# Altium schematic sheet MainProcessor.SchDoc(MainProcessor)
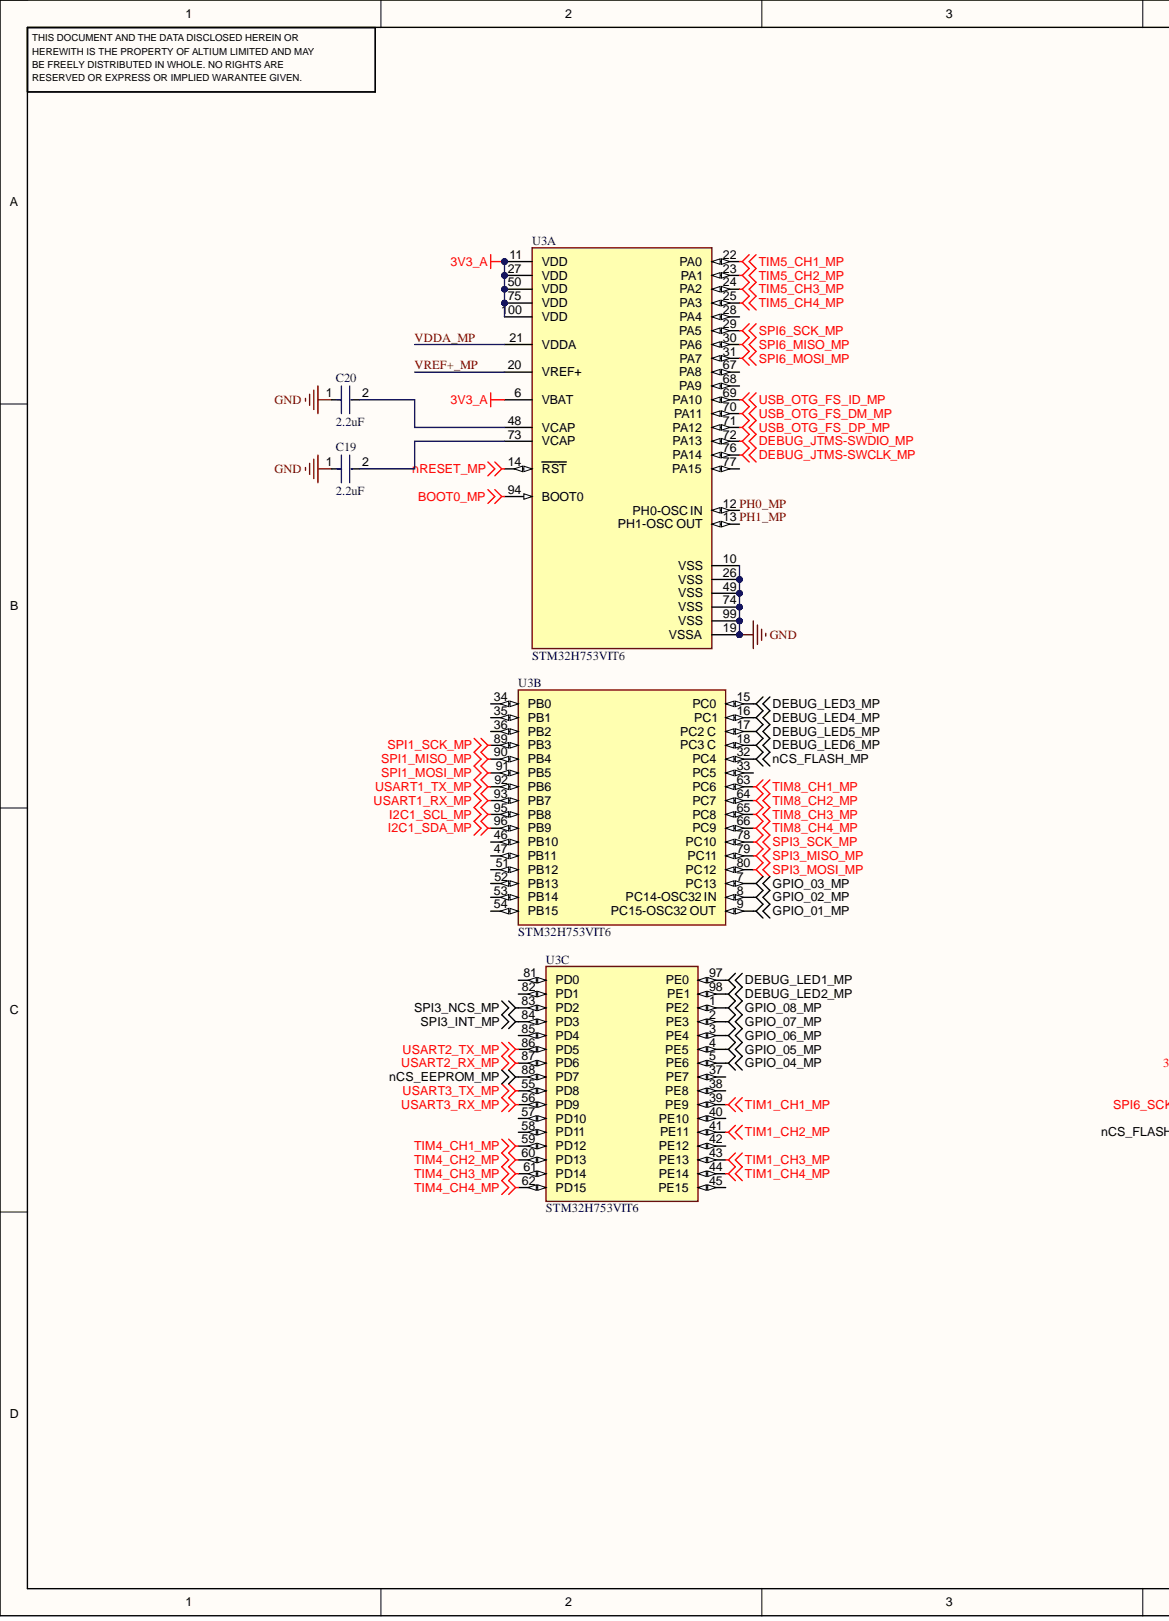
[diagram p0: MainProcessor.SchDoc, left]
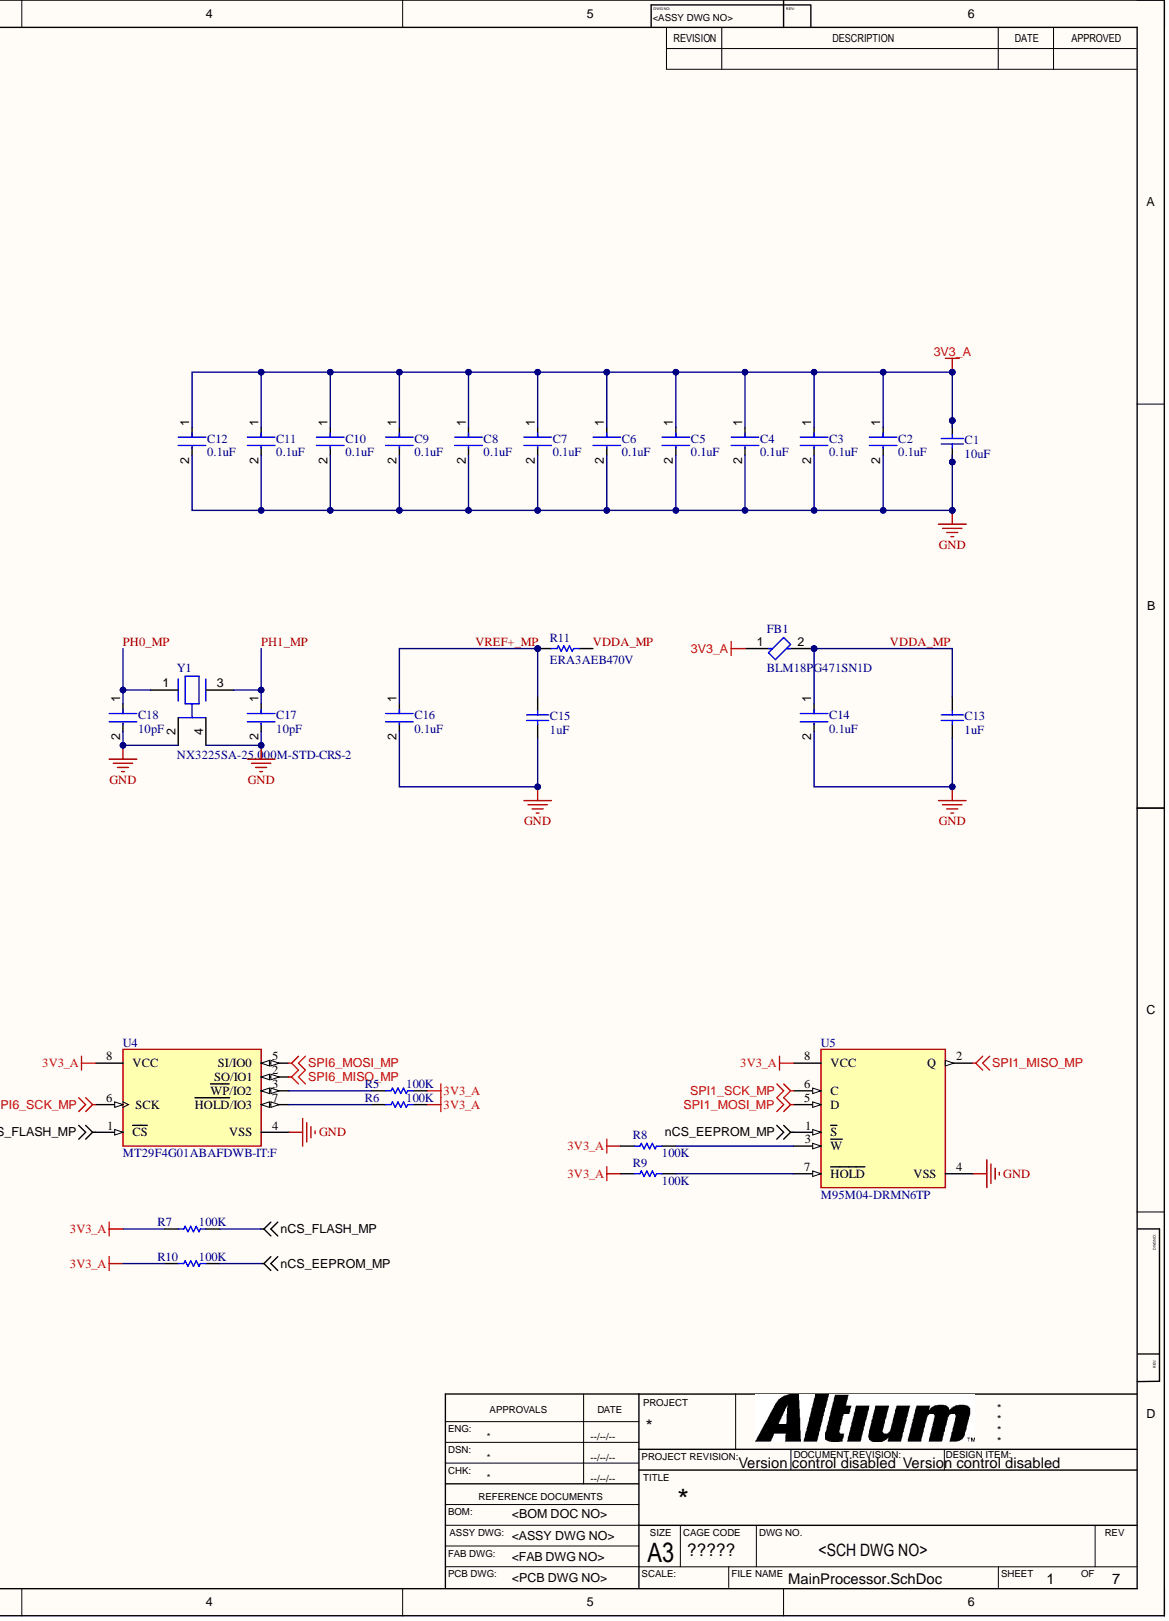
[diagram p1: MainProcessor.SchDoc, right]

## Parts
C1  10uF
C2  0.1uF
C3  0.1uF
C4  0.1uF
C5  0.1uF
C6  0.1uF
C7  0.1uF
C8  0.1uF
C9  0.1uF
C10  0.1uF
C11  0.1uF
C12  0.1uF
C13  1uF
C14  0.1uF
C15  1uF
C16  0.1uF
C17  10pF
C18  10pF
C19  2.2uF
C20  2.2uF
FB1  BLM18PG471SN1D
R5  100K
R6  100K
R7  100K
R8  100K
R9  100K
R10  100K
R11  ERA3AEB470V
U3  STM32H753VIT6  (parts A,B,C)
U4  MT29F4G01ABAFDWB-IT:F
U5  M95M04-DRMN6TP
Y1  NX3225SA-25.000M-STD-CRS-2

## Nets
3V3_A: C1.1 C2.1 C3.1 C4.1 C5.1 C6.1 C7.1 C8.1 C9.1 C10.1 C11.1 C12.1 FB1.1 R5.2 R6.2 R7.2 R8.2 R9.2 R10.2 U3.6(VBAT) U3.11(VDD) U3.27(VDD) U3.50(VDD) U3.75(VDD) U3.100(VDD) U4.8(VCC) U5.8(VCC)
BOOT0_MP: U3.94(BOOT0)
DEBUG_JTMS-SWCLK_MP: U3.76(PA14)
DEBUG_JTMS-SWDIO_MP: U3.72(PA13)
DEBUG_LED1_MP: U3.97(PE0)
DEBUG_LED2_MP: U3.98(PE1)
DEBUG_LED3_MP: U3.15(PC0)
DEBUG_LED4_MP: U3.16(PC1)
DEBUG_LED5_MP: U3.17(PC2_C)
DEBUG_LED6_MP: U3.18(PC3_C)
GND: C1.2 C2.2 C3.2 C4.2 C5.2 C6.2 C7.2 C8.2 C9.2 C10.2 C11.2 C12.2 C13.2 C14.2 C15.2 C16.2 C17.2 C18.2 C19.1 C20.1 U3.10(VSS) U3.19(VSSA) U3.26(VSS) U3.49(VSS) U3.74(VSS) U3.99(VSS) U4.4(VSS) U5.4(VSS) Y1.2 Y1.4
GPIO_01_MP: U3.9(PC15-OSC32_OUT)
GPIO_02_MP: U3.8(PC14-OSC32_IN)
GPIO_03_MP: U3.7(PC13)
GPIO_04_MP: U3.5(PE6)
GPIO_05_MP: U3.4(PE5)
GPIO_06_MP: U3.3(PE4)
GPIO_07_MP: U3.2(PE3)
GPIO_08_MP: U3.1(PE2)
I2C1_SCL_MP: U3.95(PB8)
I2C1_SDA_MP: U3.96(PB9)
nCS_EEPROM_MP: R10.1 U3.88(PD7) U5.1(S)
nCS_FLASH_MP: R7.1 U3.32(PC4) U4.1(CS)
nRESET_MP: U3.14(RST)
SPI1_MISO_MP: U3.90(PB4) U5.2(Q)
SPI1_MOSI_MP: U3.91(PB5) U5.5(D)
SPI1_SCK_MP: U3.89(PB3) U5.6(C)
SPI3_INT_MP: U3.84(PD3)
SPI3_MISO_MP: U3.79(PC11)
SPI3_MOSI_MP: U3.80(PC12)
SPI3_NCS_MP: U3.83(PD2)
SPI3_SCK_MP: U3.78(PC10)
SPI6_MISO_MP: U3.30(PA6) U4.2(SO/IO1)
SPI6_MOSI_MP: U3.31(PA7) U4.5(SI/IO0)
SPI6_SCK_MP: U3.29(PA5) U4.6(SCK)
TIM1_CH1_MP: U3.39(PE9)
TIM1_CH2_MP: U3.41(PE11)
TIM1_CH3_MP: U3.43(PE13)
TIM1_CH4_MP: U3.44(PE14)
TIM4_CH1_MP: U3.59(PD12)
TIM4_CH2_MP: U3.60(PD13)
TIM4_CH3_MP: U3.61(PD14)
TIM4_CH4_MP: U3.62(PD15)
TIM5_CH1_MP: U3.22(PA0)
TIM5_CH2_MP: U3.23(PA1)
TIM5_CH3_MP: U3.24(PA2)
TIM5_CH4_MP: U3.25(PA3)
TIM8_CH1_MP: U3.63(PC6)
TIM8_CH2_MP: U3.64(PC7)
TIM8_CH3_MP: U3.65(PC8)
TIM8_CH4_MP: U3.66(PC9)
USART1_RX_MP: U3.93(PB7)
USART1_TX_MP: U3.92(PB6)
USART2_RX_MP: U3.87(PD6)
USART2_TX_MP: U3.86(PD5)
USART3_RX_MP: U3.56(PD9)
USART3_TX_MP: U3.55(PD8)
USB_OTG_FS_DM_MP: U3.70(PA11)
USB_OTG_FS_DP_MP: U3.71(PA12)
USB_OTG_FS_ID_MP: U3.69(PA10)
PH0_MP: C18.1 U3.12(PH0-OSC_IN) Y1.1
PH1_MP: C17.1 U3.13(PH1-OSC_OUT) Y1.3
VDDA_MP: C13.1 C14.1 FB1.2 R11.2 U3.21(VDDA)
VREF+_MP: C15.1 C16.1 R11.1 U3.20(VREF+)
NetC19_2: C19.2 U3.73(VCAP)
NetC20_2: C20.2 U3.48(VCAP)
NetR5_1: R5.1 U4.3(WP/IO2)
NetR6_1: R6.1 U4.7(HOLD/IO3)
NetR8_1: R8.1 U5.3(W)
NetR9_1: R9.1 U5.7(HOLD)

Not connected: U3 28(PA4) 33(PC5) 34(PB0) 35(PB1) 36(PB2) 37(PE7) 38(PE8) 40(PE10) 42(PE12) 45(PE15) 46(PB10) 47(PB11) 51(PB12) 52(PB13) 53(PB14) 54(PB15) 57(PD10) 58(PD11) 67(PA8) 68(PA9) 77(PA15) 81(PD0) 82(PD1) 85(PD4)

## Library data of the parts
C1 = CMP-2007-01044-1: Manufacturer: Kemet; Part Number: C0805C106K8RACTU; Component Kind: Standard; Library Name: Altium Content Vault
C2-C12 C14 C16 = CMP-2006-03061-2: CAP CER 0.1UF 50V X7R 0603; Manufacturer: KEMET; Manufacturer Part Number: C0603C104K5RACTU; Automotive Grade: Grade 1; Capacitance: 0.10 μF; Material: Ceramic; Temperature Coefficient: 30ppm/°C; Tolerance: 0.1; Value: 0.10 μF; Automotive: Yes; Category: Cap; Component Kind: Standard; ComponentLink2Description: Datasheet; ComponentLink2URL: https://api.kemet.com/component-edge/download/datasheet/C0603C100J5GACAUTO.pdf; Device Class L1: Passive Components; Device Class L2: Capacitors; Device Class L3: Ceramic Capacitors; Digikey Description: CAP CER 0.1UF 50V X7R 0603; Height: 0.87mm; Lead Free: Yes; Library Name: Altium Content Vault; Octopart: https://octopart.com/c0603c104k5ractu-kemet-139244; Package: C0603C-CF; RoHS: TRUE; Temperature: -55°C to +125°C; Temperature Characteristic: X7R; Temperature Range High: +125°C; Temperature Range Low: -55°C; Voltage Rating: 50 V
C13 C15 = CMP-2006-02298-2: Manufacturer: Kemet; Part Number: C0603C105K4RACTU; Component Kind: Standard; Library Name: Altium Content Vault
C17 C18 = CMP-2006-03343-2: CAP CER 10PF 50V NP0 0603; Manufacturer: KEMET; Manufacturer Part Number: C0603C100C5GACTU; Automotive Grade: Grade 1; Material: Ceramic; Temperature Characteristic: C0G; Temperature Coefficient: 30ppm/°C; Tolerance: 0.25 pF; Automotive: Yes; Capacitance: 10 pF; Category: Cap; Component Kind: Standard; ComponentLink2Description: Datasheet; ComponentLink2URL: https://api.kemet.com/component-edge/download/datasheet/C0603C100J5GACAUTO.pdf; Device Class L1: Passive Components; Device Class L2: Capacitors; Device Class L3: Ceramic Capacitors; Digikey Description: CAP CER 10PF 50V NP0 0603; Height: 0.87mm; Lead Free: Yes; Library Name: Altium Content Vault; Mouser Description: Multilayer Ceramic Capacitors MLCC - SMD/SMT 50volts 10pF C0G 0.25%; Octopart: https://octopart.com/c0603c100c5gactu-kemet-9138556; Package: C0603C-CF; RoHS: TRUE; Temperature: -55°C to +125°C; Temperature Range High: +125°C; Temperature Range Low: -55°C; Value: 10 pF; Voltage Rating: 50 V
C19 C20 = CMP-2006-02836-2: Chip Multilayer Ceramic Capacitors for General Purpose, 0603, 2.2uF, X7R, 15%, 10%, 10V; Capacitance: 2.2uF; Case Code (Imperial): 0603; Case Code (Metric): 1608; Case/Package: 0603; Component Kind: Standard; Depth: 0.8mm; Dielectric: X7R; Height: 0.8mm; Lead Free: Lead Free; Length: 1.6mm; Library Name: Altium Content Vault; Material: Ceramic; Max Operating Temperature: 125°C; Min Operating Temperature: -55°C; Mount: Surface Mount; Number of Pins: 2; Package Quantity: 1; Packaging: Cut Tape; REACH SVHC: No SVHC; Termination: SMD/SMT; Thickness: 0.035inch; Tolerance: 10%; Voltage: 10V; Voltage Rating: 10V; Voltage Rating (DC): 10V; Weight: 7.1E-05oz; Width: 0.031inch
FB1 = CMP-0686-00587-3: Chip Ferrite Bead, 0603, 470Ω @ 100MHz, 0.2Ω, 25%, 1A; DC Resistance (DCR): 200mR; Impedance: 470R; Material: Steel; Number of Circuits: 1; Case Code (Imperial): 0603; Case Code (Metric): 1608; Case/Package: 0603; Component Kind: Standard; Core Material: Ferrite; Current Rating: 1A; DC Current: 1A; DC Resistance-Max: 200mR; Depth: 0.8mm; Height: 0.8mm; Lead Free: Lead Free; Length: 1.6mm; Library Name: Altium Content Vault; Max Current Rating: 1A; Max DC Current: 1A; Max Operating Temperature: 125°C; Military Standard: Not; Min Operating Temperature: -55°C; Number of Lines: 1; Number of Pins: 2; Package Quantity: 1; Packaging: Tape and Reel; Radiation Hardening: No; REACH SVHC: No SVHC; Resistance: 200mR; RoHS Compliant: Yes; Series: BLM18P; Termination: SMD/SMT; Test Frequency: 100MHz; Tolerance: 25%; Width: 0.8mm
R5-R10 = CMP-2000-01852-1: Manufacturer: KOA Speer; Part Number: RK73B1JTTD104J; Component Kind: Standard; Library Name: Altium Content Vault
R11 = CMP-2000-00070-1: Manufacturer: Panasonic; Component Kind: Standard; Library Name: Altium Content Vault; Part Number: ERA3AEB470V
U3 = CMP-12105-000037-1: LQFP 100 14X14X1.4; Manufacturer: STMicroelectronics; Core Architecture: ARM Cortex-M7; Data Bus Width: 32bits; Frequency: 480MHz; Interface: I2C,SPI,UART,USART,CAN,USB,Ethernet,I2S,Other; Max Junction Temp: +125°C; Max Supply Voltage: 3.6V; Memory Size: 2MBytes; Memory Type: FLASH; Min Supply Voltage: 1.71V; Number Of A/D Converters: 5; Number Of Adc Channels: 36; Number Of Cores: 1; Number Of I2C Channels: 4; Number Of I/Os: 82; Number Of Pwm Channels: 2; Number Of Spi Channels: 6; Number Of Timers/Counters: 20; Ram Size: 1MBytes; Standoff Height: 0.05mm; Ambient Temperature Range High: +85°C; Ambient Temperature Range Low: -40°C; Automotive: No; Category: IC; Component Kind: Standard; ComponentLink2Description: Datasheet; ComponentLink2URL: https://www.st.com/resource/en/datasheet/stm32h753vi.pdf; Device Class L1: Integrated Circuits (ICs); Device Class L2: Embedded Processors and Controllers; Device Class L3: Microcontrollers; Digikey Description: LQFP 100 14X14X1.4; Height: 1.6mm; Ipc Land Pattern Name: QFP50P1600X1600X160-100; Lead Free: Yes; Library Name: Altium Content Vault; Manufacturer Part Number: STM32H753VIT6; Mouser Description: MCU 32-Bit STM32 ARM Cortex M7 RISC 2MB Flash 1.8V/2.5V/3.3V 100-Pin LQFP Tray; Number Of Uart Channels: 4; Octopart: https://octopart.com/stm32h753vit6-stmicroelectronics-87879769; Package: LQFP100-1L; RoHS: TRUE; Temperature: -40°C to +85°C; Temperature Range High: +85°C; Temperature Range Low: -40°C
U4 = CMP-14958-000017-1: IC FLASH 4GBIT SPI UPDFN; Memory Size: 4Gb; Memory Type: Non-Volatile; Component Kind: Standard; Library Name: Altium Content Vault; Max Operating Temperature: 85°C; Min Operating Temperature: -40°C; Packaging: Box; Series: -
U5 = CMP-12142-000020-1: STANDARD SERIAL EEPROM; Component Kind: Standard; Library Name: Altium Content Vault; RoHS Compliant: Yes
Y1 = CMP-200220-000002-1: CRYSTAL 25.0000MHZ 8PF SMD; Manufacturer: NDK; Automotive Grade: Grade 1; Esr: 100Ω; Frequency: 25MHz; Tolerance: 15ppm; Automotive: Yes; Category: Crys; Component Kind: Standard; ComponentLink2Description: Datasheet; ComponentLink2URL: https://www.ndk.com/images/products/catalog/c_NX3225SA-STD-CRS-2_e.pdf; Device Class L1: Passive Components; Device Class L2: Crystals / Oscillators; Device Class L3: Crystals; Digikey Description: CRYSTAL 25.0000MHZ 8PF SMD; Height: 0.6mm; Lead Free: Yes; Library Name: Altium Content Vault; Load Capacitance: 8pF; Manufacturer Part Number: NX3225SA-25.000M-STD-CRS-2; Octopart: https://octopart.com/nx3225sa-25.000m-std-crs-2-ndk-51374293; Package: NX3225SA; RoHS: TRUE; Series: NX3225SA; Temperature: -40°C to +125°C; Temperature Range High: +125°C; Temperature Range Low: -40°C
